# Altium schematic sheet CPU.SchDoc(CPU)
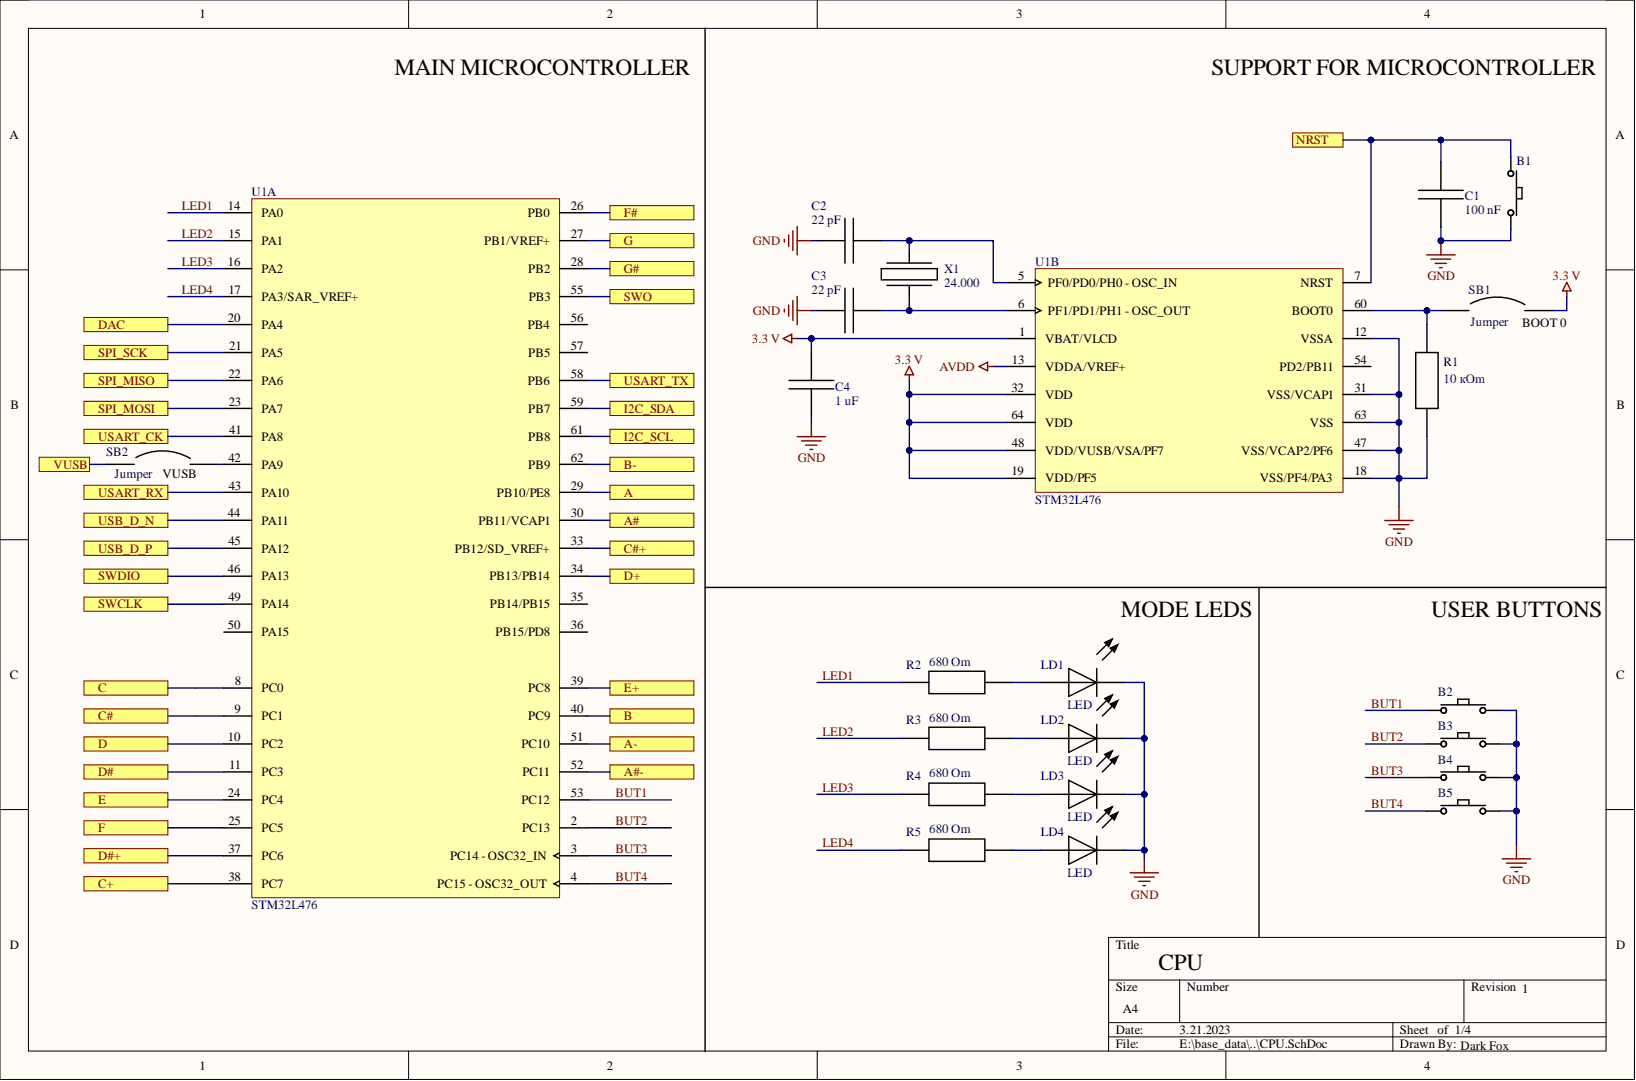
[diagram p0: CPU.SchDoc]

## Parts
B1  but  [TS44-SMD]  (value not drawn)
B2  but  [TS44-SMD]  (value not drawn)
B3  but  [TS44-SMD]  (value not drawn)
B4  but  [TS44-SMD]  (value not drawn)
B5  but  [TS44-SMD]  (value not drawn)
C1  100 nF  [0603]
C2  22 pF  [0805]
C3  22 pF  [0805]
C4  1 uF  [0603]
LD1  LED  [0805]
LD2  LED  [0805]
LD3  LED  [0805]
LD4  LED  [0805]
R1  10 кOm  [0603]
R2  680 Om  [1206]
R3  680 Om  [1206]
R4  680 Om  [1206]
R5  680 Om  [1206]
SB1  Jumper  [SB]
SB2  Jumper  [SB]
U1  STM32L476  [LQFP - 64]  (parts A,B)
X1  24.000  [CSX-3225]

## Ports
A, A#, A#-, A-, B, B-, C, C#, C#+, C+, D, D#, D#+, D+, DAC, E, E+, F, F#, G, G#, I2C_SCL, I2C_SDA, NRST, SPI_MISO, SPI_MOSI, SPI_SCK, SWCLK, SWDIO, SWO, USART_CK, USART_RX, USART_TX, USB_D_N, USB_D_P, VUSB

## Nets
3.3 V: C4.2 SB1.2 U1.1(VBAT/VLCD) U1.19(VDD/PF5) U1.32(VDD) U1.48(VDD/VUSB/VSA/PF7) U1.64(VDD)
AVDD: U1.13(VDDA/VREF+)
GND: B1.2 B2.2 B3.2 B4.2 B5.2 C1.1 C2.1 C3.1 C4.1 LD1.2 LD2.2 LD3.2 LD4.2 R1.1 U1.12(VSSA) U1.18(VSS/PF4/PA3) U1.31(VSS/VCAP1) U1.47(VSS/VCAP2/PF6) U1.63(VSS)
A: U1.29(PB10/PE8) ; port A
A#: U1.30(PB11/VCAP1) ; port A#
A#-: U1.52(PC11) ; port A#-
A-: U1.51(PC10) ; port A-
B: U1.40(PC9) ; port B
B-: U1.62(PB9) ; port B-
BUT1: B2.1 U1.53(PC12)
BUT2: B3.1 U1.2(PC13)
BUT3: B4.1 U1.3(PC14_-_OSC32_IN)
BUT4: B5.1 U1.4(PC15_-_OSC32_OUT)
C: U1.8(PC0) ; port C
C#: U1.9(PC1) ; port C#
C#+: U1.33(PB12/SD_VREF+) ; port C#+
C+: U1.38(PC7) ; port C+
D: U1.10(PC2) ; port D
D#: U1.11(PC3) ; port D#
D#+: U1.37(PC6) ; port D#+
D+: U1.34(PB13/PB14) ; port D+
DAC: U1.20(PA4) ; port DAC
E: U1.24(PC4) ; port E
E+: U1.39(PC8) ; port E+
F: U1.25(PC5) ; port F
F#: U1.26(PB0) ; port F#
G: U1.27(PB1/VREF+) ; port G
G#: U1.28(PB2) ; port G#
I2C_SCL: U1.61(PB8) ; port I2C_SCL
I2C_SDA: U1.59(PB7) ; port I2C_SDA
LED1: R2.1 U1.14(PA0)
LED2: R3.1 U1.15(PA1)
LED3: R4.1 U1.16(PA2)
LED4: R5.1 U1.17(PA3/SAR_VREF+)
NRST: B1.1 C1.2 U1.7(NRST) ; port NRST
SPI_MISO: U1.22(PA6) ; port SPI_MISO
SPI_MOSI: U1.23(PA7) ; port SPI_MOSI
SPI_SCK: U1.21(PA5) ; port SPI_SCK
SWCLK: U1.49(PA14) ; port SWCLK
SWDIO: U1.46(PA13) ; port SWDIO
SWO: U1.55(PB3) ; port SWO
USART_CK: U1.41(PA8) ; port USART_CK
USART_RX: U1.43(PA10) ; port USART_RX
USART_TX: U1.58(PB6) ; port USART_TX
USB_D_N: U1.44(PA11) ; port USB_D_N
USB_D_P: U1.45(PA12) ; port USB_D_P
VUSB: SB2.1 ; port VUSB
NetC2_2: C2.2 U1.5(PF0/PD0/PH0_-_OSC_IN) X1.2
NetC3_2: C3.2 U1.6(PF1/PD1/PH1_-_OSC_OUT) X1.1
NetLD1_1: LD1.1 R2.2
NetLD2_1: LD2.1 R3.2
NetLD3_1: LD3.1 R4.2
NetLD4_1: LD4.1 R5.2
NetR1_2: R1.2 SB1.1 U1.60(BOOT0)
NetSB2_2: SB2.2 U1.42(PA9)

Not connected: U1 35(PB14/PB15) 36(PB15/PD8) 50(PA15) 54(PD2/PB11) 56(PB4) 57(PB5)

## Library data of the parts
C1-C4 = Cup: Component Kind: Standard; Library Name: MyLib.SchLib
R1-R5 = Res: Component Kind: Standard; Library Name: MyLib.SchLib
U1 = STM32L476RG: The ultra-low-power microcontrollers based on the highperformance Arm Cortex-M4 32-bit RISC core operating at a frequency of up to 80 MHz.; Component Kind: Standard; Library Name: MyLib.SchLib
X1 = CSX-3225: Crystal Resonator; Component Kind: Standard; Library Name: MyLib.SchLib
B1-B5 = but: Component Kind: Standard; Library Name: MyLib.SchLib
LD1-LD4 = LED: Component Kind: Standard; Library Name: MyLib.SchLib
SB1 SB2 = Jumper: Component Kind: Standard; Library Name: MyLib.SchLib
